# Altium schematic sheet MCU.SchDoc
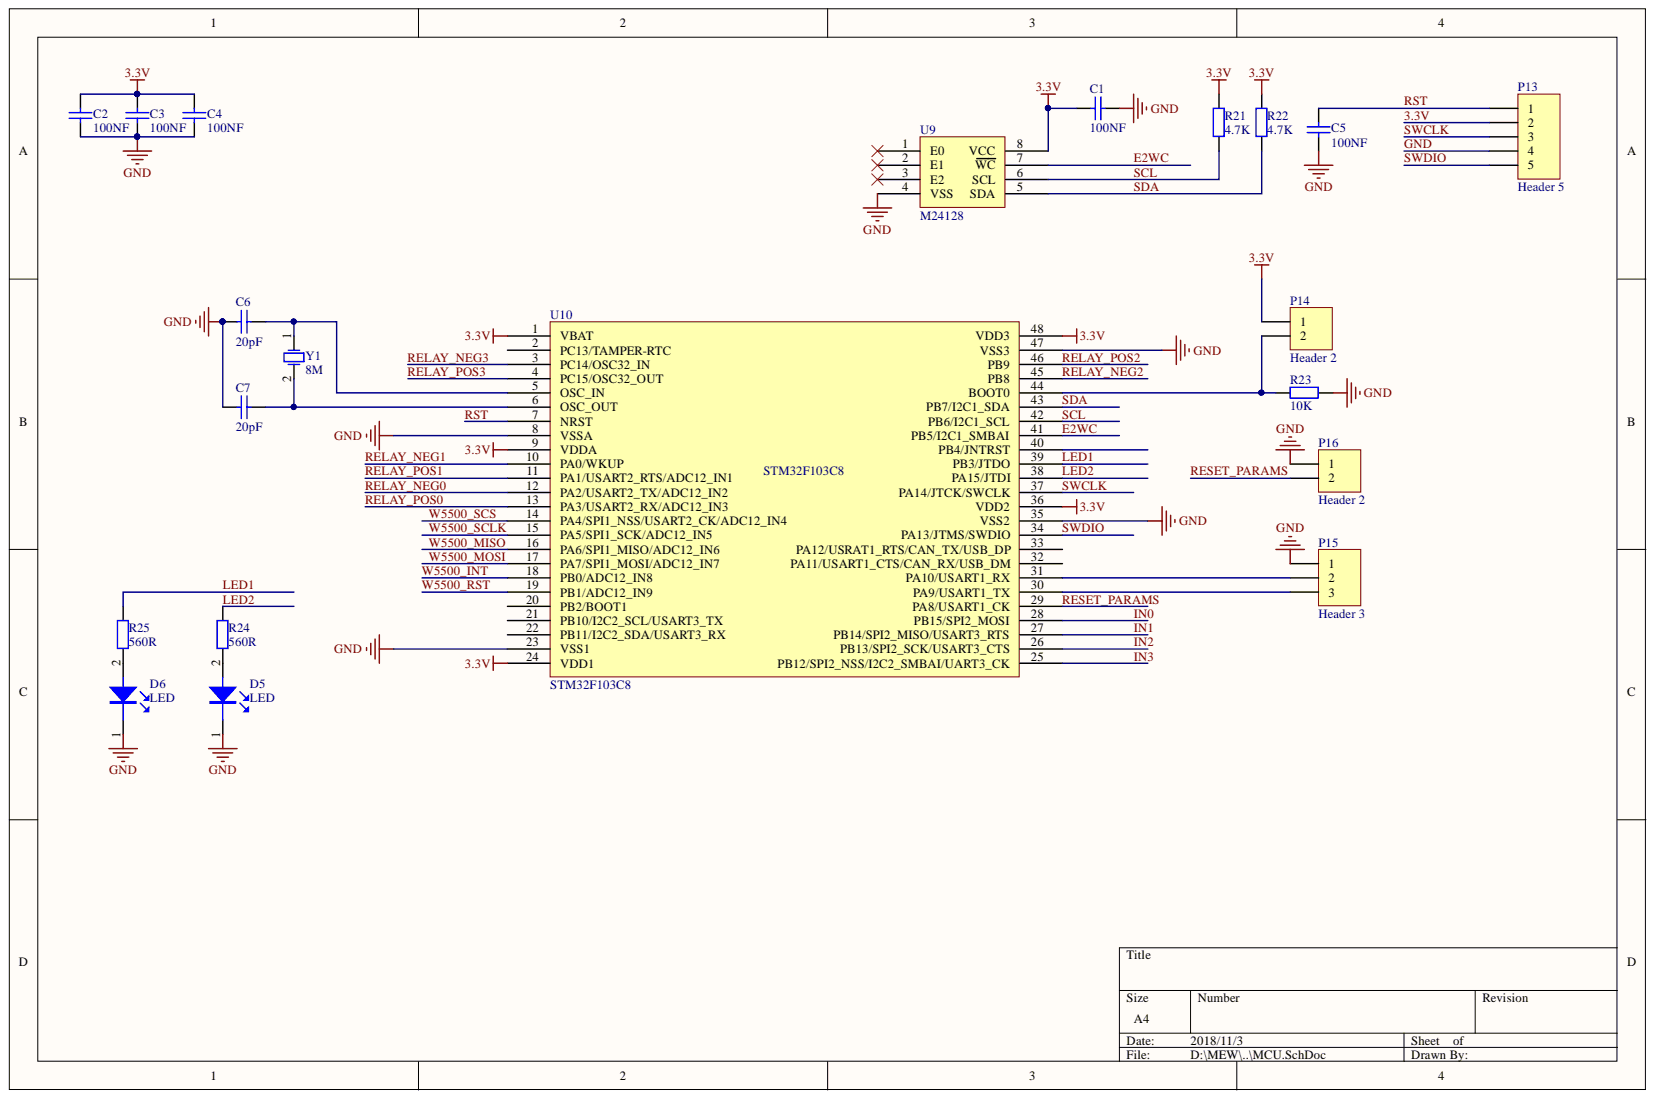
[diagram p0: MCU.SchDoc]

## Parts
C1  100NF  [RC0603]
C2  100NF  [RC0603]
C3  100NF  [RC0603]
C4  100NF  [RC0603]
C5  100NF  [RC0603]
C6  20pF  [RC0603]
C7  20pF  [RC0603]
D5  LED  [LED_SMT_0603]
D6  LED  [LED_SMT_0603]
P13  Header 5  [HDR1X5]
P14  Header 2  [HDR1X2]
P15  Header 3  [HDR1X3]
P16  Header 2  [HDR1X2]
R21  4.7K  [RC0603]
R22  4.7K  [RC0603]
R23  10K  [RC0603]
R24  560R  [RC0603]
R25  560R  [RC0603]
U9  M24128  [M24128-SOIC8]
U10  STM32F103C8  [STM32F030C8]
Y1  8M  [XTAL-3225]

## Nets
3.3V: C1.1 C2.1 C3.1 C4.1 P13.2 P14.1 R21.1 R22.1 U9.8(VCC) U10.1(VBAT) U10.9(VDDA) U10.24(VDD1) U10.36(VDD2) U10.48(VDD3)
E2WC: U9.7(WC) U10.41(PB5/I2C1_SMBAI)
GND: C1.2 C2.2 C3.2 C4.2 C5.2 C6.1 C7.1 D5.1 D6.1 P13.4 P15.1 P16.1 R23.1 U9.4(VSS) U10.8(VSSA) U10.23(VSS1) U10.35(VSS2) U10.47(VSS3)
IN0: U10.28(PB15/SPI2_MOSI)
IN1: U10.27(PB14/SPI2_MISO/USART3_RTS)
IN2: U10.26(PB13/SPI2_SCK/USART3_CTS)
IN3: U10.25(PB12/SPI2_NSS/I2C2_SMBAI/UART3_CK)
LED1: R25.2 U10.39(PB3/JTDO)
LED2: R24.2 U10.38(PA15/JTDI)
RELAY_NEG0: U10.12(PA2/USART2_TX/ADC12_IN2)
RELAY_NEG1: U10.10(PA0/WKUP)
RELAY_NEG2: U10.45(PB8)
RELAY_NEG3: U10.3(PC14/OSC32_IN)
RELAY_POS0: U10.13(PA3/USART2_RX/ADC12_IN3)
RELAY_POS1: U10.11(PA1/USART2_RTS/ADC12_IN1)
RELAY_POS2: U10.46(PB9)
RELAY_POS3: U10.4(PC15/OSC32_OUT)
RESET_PARAMS: P16.2 U10.29(PA8/USART1_CK)
RST: C5.1 P13.1 U10.7(NRST)
SCL: R21.2 U9.6(SCL) U10.42(PB6/I2C1_SCL)
SDA: R22.2 U9.5(SDA) U10.43(PB7/I2C1_SDA)
SWCLK: P13.3 U10.37(PA14/JTCK/SWCLK)
SWDIO: P13.5 U10.34(PA13/JTMS/SWDIO)
W5500_INT: U10.18(PB0/ADC12_IN8)
W5500_MISO: U10.16(PA6/SPI1_MISO/ADC12_IN6)
W5500_MOSI: U10.17(PA7/SPI1_MOSI/ADC12_IN7)
W5500_RST: U10.19(PB1/ADC12_IN9)
W5500_SCLK: U10.15(PA5/SPI1_SCK/ADC12_IN5)
W5500_SCS: U10.14(PA4/SPI1_NSS/USART2_CK/ADC12_IN4)
NetC6_2: C6.2 U10.5(OSC_IN) Y1.1
NetC7_2: C7.2 U10.6(OSC_OUT) Y1.2
NetD5_2: D5.2 R24.1
NetD6_2: D6.2 R25.1
NetP14_2: P14.2 R23.2 U10.44(BOOT0)
NetP15_2: P15.2 U10.31(PA10/USART1_RX)
NetP15_3: P15.3 U10.30(PA9/USART1_TX)

Not connected: U9 1(E0) 2(E1) 3(E2); U10 2(PC13/TAMPER-RTC) 20(PB2/BOOT1) 21(PB10/I2C2_SCL/USART3_TX) 22(PB11/I2C2_SDA/USART3_RX) 32(PA11/USART1_CTS/CAN_RX/USB_DM) 33(PA12/USRAT1_RTS/CAN_TX/USB_DP) 40(PB4/JNTRST)

## Library data of the parts
C1-C7 = CAP-ceramic: Component Kind: Standard; Library Name: HFNADLIB.SchLib
P13 = Header 5: Header, 5-Pin; Component Kind: Standard; LatestRevisionDate: 17-Jul-2002; LatestRevisionNote: Re-released for DXP Platform.; Library Name: Miscellaneous Connectors.IntLib; Signal Integrity: Connector; Publisher: Altium Limited
P14 P16 = Header 2: Header, 2-Pin; Component Kind: Standard; LatestRevisionDate: 17-Jul-2002; LatestRevisionNote: Re-released for DXP Platform.; Library Name: Miscellaneous Connectors.IntLib; Signal Integrity: Connector; Publisher: Altium Limited
P15 = Header 3: Header, 3-Pin; Component Kind: Standard; LatestRevisionDate: 17-Jul-2002; LatestRevisionNote: Re-released for DXP Platform.; Library Name: Miscellaneous Connectors.IntLib; Signal Integrity: Connector; Publisher: Altium Limited
R21-R25 = RES: Component Kind: Standard; Library Name: HFNADLIB.SchLib
Y1 = XTAL: Component Kind: Standard; Library Name: HFNADLIB.SchLib
D5 D6 = LED: Component Kind: Standard; Library Name: HFNADLIB.SchLib
U9 = M24128: Component Kind: Standard; Library Name: HFNADLIB.SchLib
U10 = STM32F103C8: Component Kind: Standard; Library Name: HFNADLIB.SchLib
